# Altium schematic sheet Reefing-IO.SchDoc
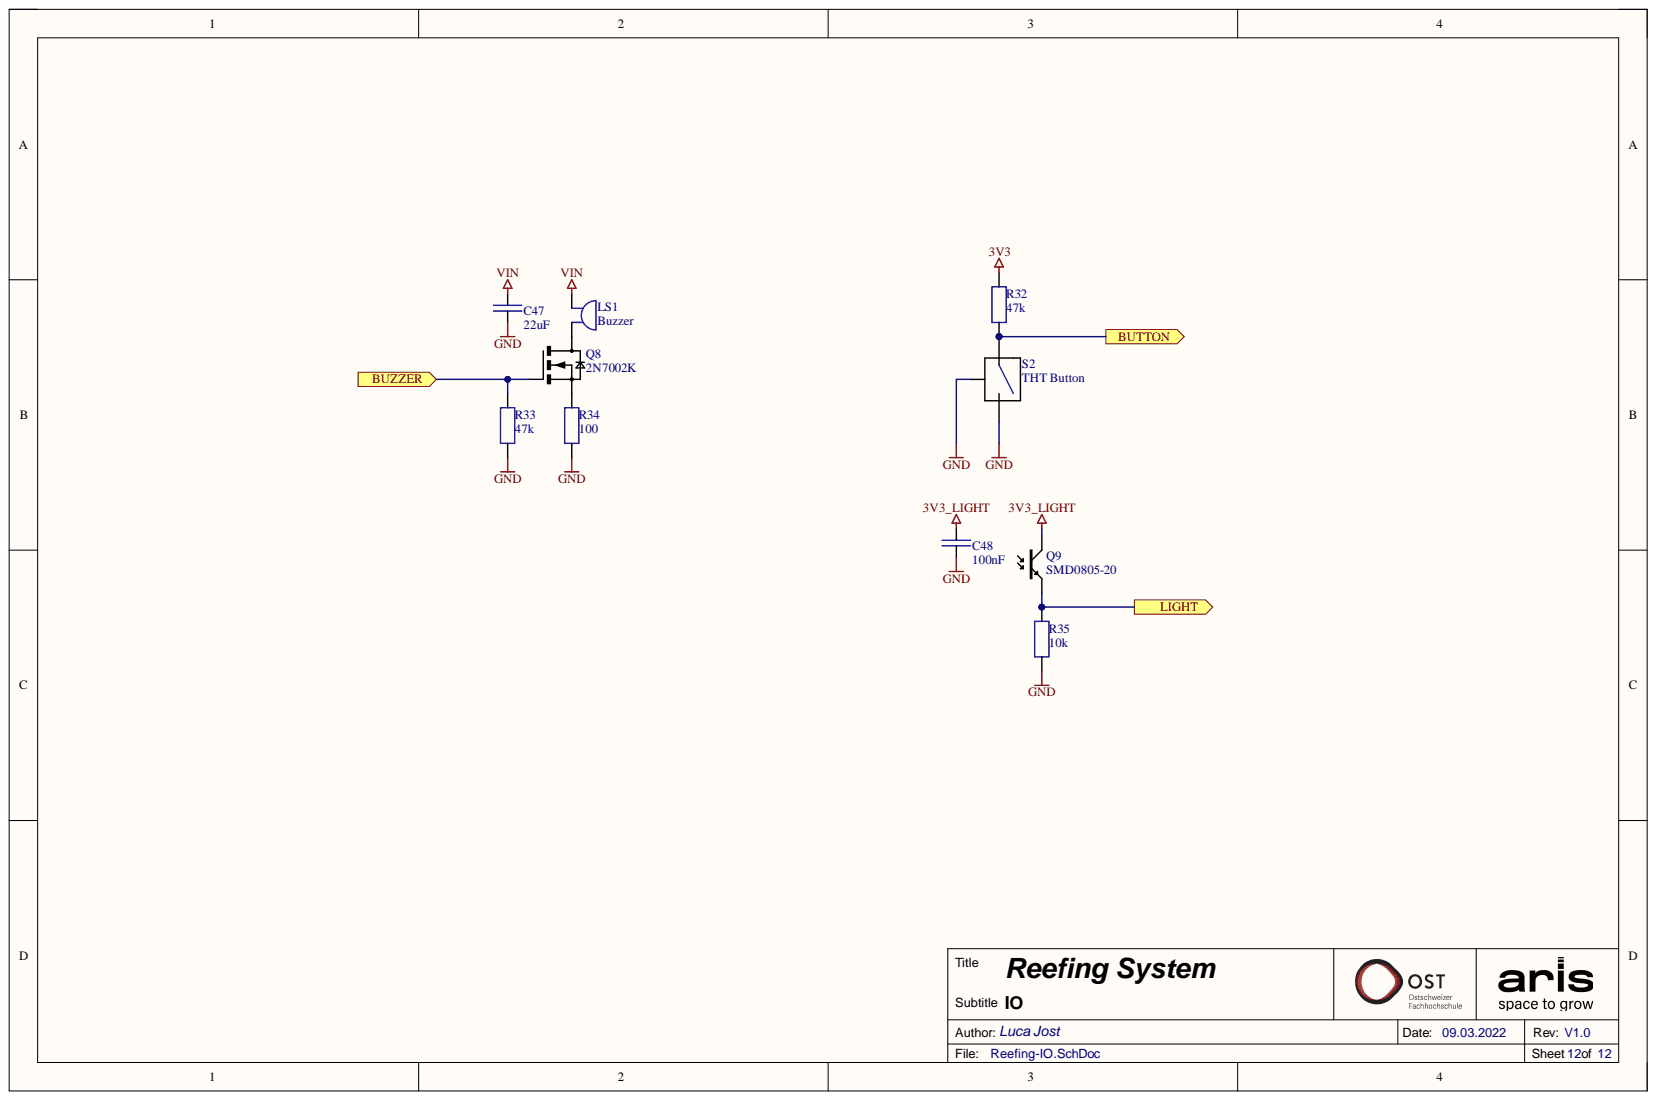
[diagram p0: Reefing-IO.SchDoc]

## Parts
C47  22uF  [SMD Keramikkondensator 0805]
C48  100nF  [SMD Keramikkondensator 0402]
LS1  Buzzer  [SMD BUZZER CMT-8504]
Q8  2N7002K  [SMD MOSFET SOT-23]
Q9  SMD0805-20  [SMD LED 0805]
R32  47k  [SMD Widerstand 0805]
R33  47k  [SMD Widerstand 0805]
R34  100  [SMD Widerstand 0805]
R35  10k  [SMD Widerstand 0402]
S2  THT Button  [THT Button Right Angle]

## Ports
BUTTON, BUZZER, LIGHT

## Nets
3V3: R32.1
3V3_LIGHT: C48.2 Q9.A
GND: C47.1 C48.1 R33.1 R34.1 R35.1 S2.1 S2.3
VIN: C47.2 LS1.1
BUTTON: R32.2 S2.2 ; port BUTTON
BUZZER: Q8.G R33.2 ; port BUZZER
LIGHT: Q9.K R35.2 ; port LIGHT
NetLS1_2: LS1.2 Q8.D
NetQ8_S: Q8.S R34.2

## Library data of the parts
C47 = E6 - 22uF: SMD Ceramic Capacitor E6; Component Kind: Standard; JLC: C45783; Number: -; Supplier: -; Unit Price: 0.01; Library Name: CATS-EUROC.SCHLIB
C48 = E6 - 100nF: SMD Ceramic Capacitor E6; Component Kind: Standard; JLC: C307331; Number: -; Supplier: -; Unit Price: 0.01; Library Name: CATS-EUROC.SCHLIB
LS1 = Buzzer: Buzzers Indicator, Internally Driven Piezo 12V 10mA 3kHz 100dB @ 12V, 10cm Through Hole PC Pins; Component Kind: Standard; ComponentLink1Description: Datasheet; ComponentLink1URL: https://dbunlimitedco.com/images/product_images/2D-Drawings/IP303012-1.pdf; Digikey: 2104-IP303012-1-ND; Library Name: Other.SchLib
Q8 = 2N7002K: MOSFET N-Channel 60V / 500mA; Item Description: N-Channel MOSFET; Component Kind: Standard; ComponentLink1Description: Datasheet; ComponentLink1URL: https://www.onsemi.com/pub/Collateral/NDS7002A-D.PDF; Digikey: 2N7002NCT-ND; JLC: C414015; Library Name: Electronic Switches.SchLib; Price: 0.32
R32 R33 = E24 - 47k: SMD Chip Resistor E24; Component Kind: Standard; Library Name: Resistors-E24.SchLib; Number: -; Supplier: -; Unit Price: 0.01
R34 = E24 - 100: SMD Chip Resistor E24; Component Kind: Standard; JLC: C515718; Number: -; Supplier: -; Unit Price: 0.01; Library Name: CATS-EUROC.SCHLIB
R35 = E24 - 10k: SMD Chip Resistor E24; Component Kind: Standard; JLC: C25744; Number: -; Supplier: -; Unit Price: 0.01; Library Name: CATS-EUROC.SCHLIB
S2 = Button SPST-NO Right Angle: Tactile Switch SPST-NO Side Actuated Through Hole, Right Angle; Component Kind: Standard; ComponentLink1Description: Datasheet; ComponentLink1URL: https://www.te.com/commerce/DocumentDelivery/DDEController?Action=srchrtrv&DocNm=1825027&DocType=Customer+Drawing&DocLang=English; Digikey: A109320-ND; Digikey Alt: 450-1660-ND; Library Name: Switches.SchLib
Q9 = SMD0805-20: Component Kind: Standard; ComponentLink1Description: Datasheet; ComponentLink1URL: https://datasheet.lcsc.com/lcsc/1809172049_Senba-Sensing-Tech-SMD0805-20_C250858.pdf; JLC: C250858; Library Name: Sensors.SchLib
